# Altium schematic sheet Input_scheme.SchDoc(Input_scheme)
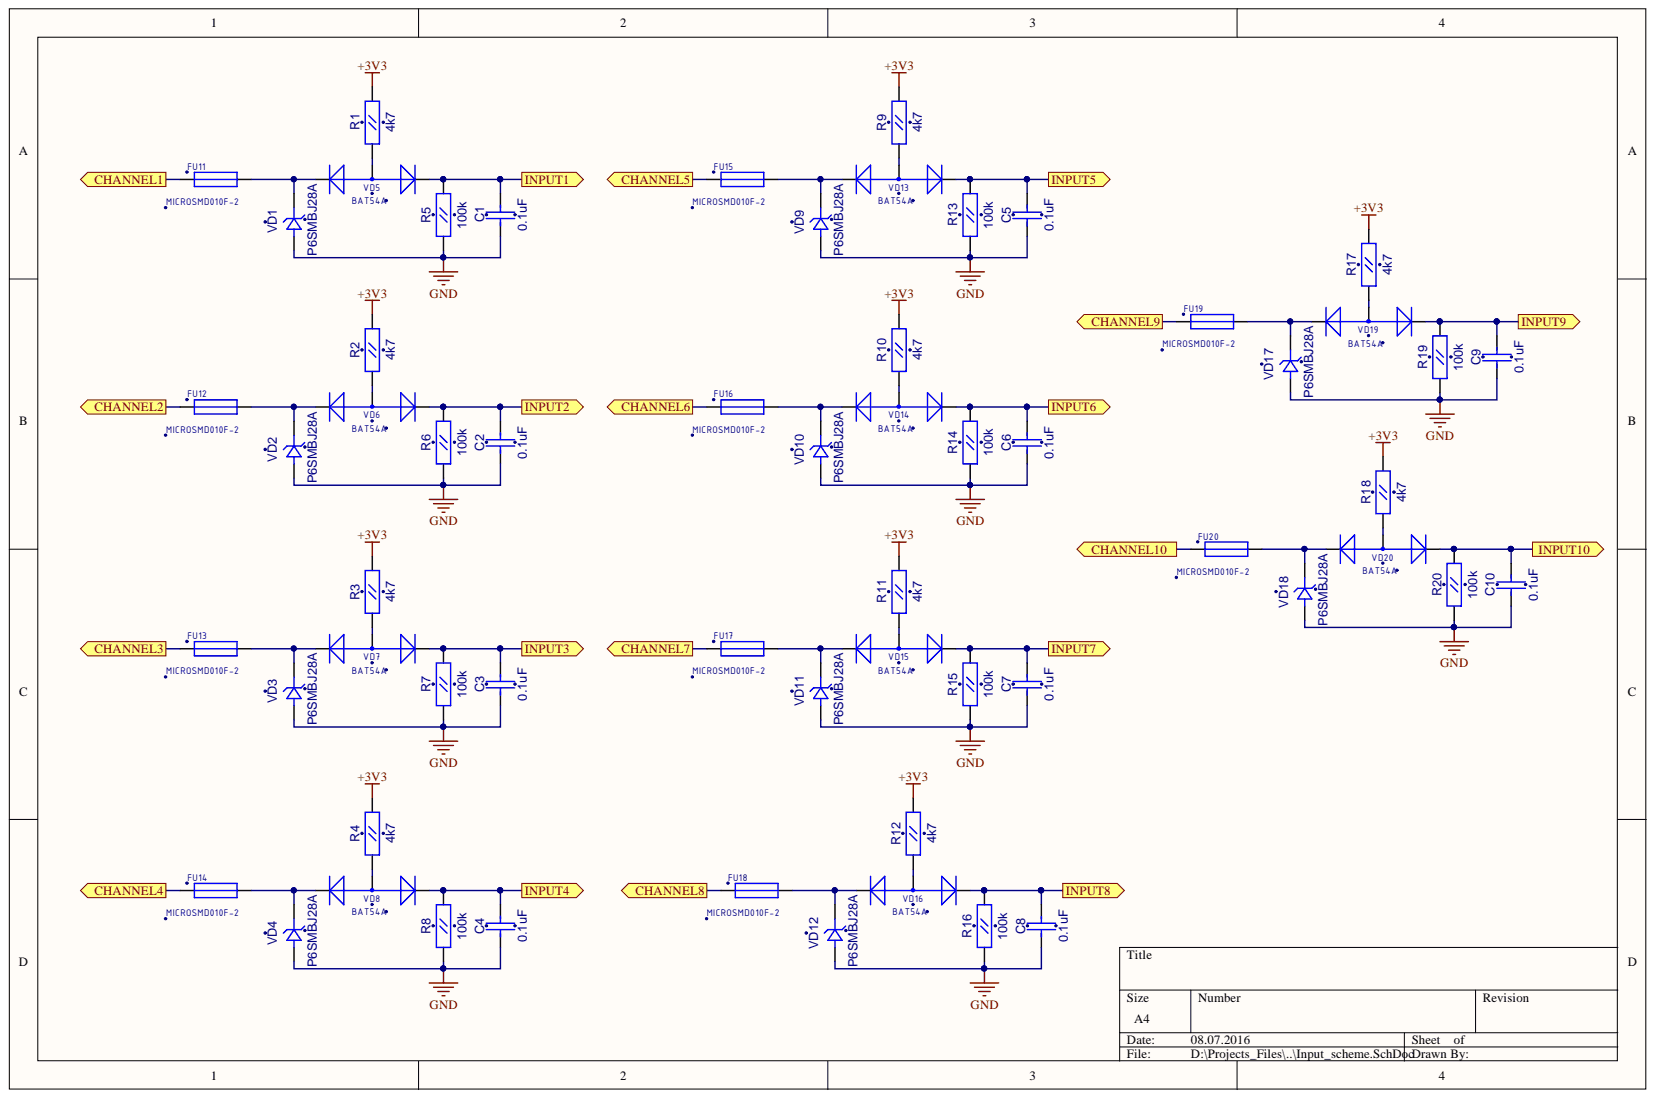
[diagram p0: Input_scheme.SchDoc]

## Parts
C1  0.1uF  [CC0603]
C2  0.1uF  [CC0603]
C3  0.1uF  [CC0603]
C4  0.1uF  [CC0603]
C5  0.1uF  [CC0603]
C6  0.1uF  [CC0603]
C7  0.1uF  [CC0603]
C8  0.1uF  [CC0603]
C9  0.1uF  [CC0603]
C10  0.1uF  [CC0603]
FU11  MICROSMD010F-2  [RC1210]
FU12  MICROSMD010F-2  [RC1210]
FU13  MICROSMD010F-2  [RC1210]
FU14  MICROSMD010F-2  [RC1210]
FU15  MICROSMD010F-2  [RC1210]
FU16  MICROSMD010F-2  [RC1210]
FU17  MICROSMD010F-2  [RC1210]
FU18  MICROSMD010F-2  [RC1210]
FU19  MICROSMD010F-2  [RC1210]
FU20  MICROSMD010F-2  [RC1210]
R1  4k7  [RC0603]
R2  4k7  [RC0603]
R3  4k7  [RC0603]
R4  4k7  [RC0603]
R5  100k  [RC0603]
R6  100k  [RC0603]
R7  100k  [RC0603]
R8  100k  [RC0603]
R9  4k7  [RC0603]
R10  4k7  [RC0603]
R11  4k7  [RC0603]
R12  4k7  [RC0603]
R13  100k  [RC0603]
R14  100k  [RC0603]
R15  100k  [RC0603]
R16  100k  [RC0603]
R17  4k7  [RC0603]
R18  4k7  [RC0603]
R19  100k  [RC0603]
R20  100k  [RC0603]
VD1  P6SMBJ28A  [SMB]
VD2  P6SMBJ28A  [SMB]
VD3  P6SMBJ28A  [SMB]
VD4  P6SMBJ28A  [SMB]
VD5  BAT54A  [SOT-23(123)]
VD6  BAT54A  [SOT-23(123)]
VD7  BAT54A  [SOT-23(123)]
VD8  BAT54A  [SOT-23(123)]
VD9  P6SMBJ28A  [SMB]
VD10  P6SMBJ28A  [SMB]
VD11  P6SMBJ28A  [SMB]
VD12  P6SMBJ28A  [SMB]
VD13  BAT54A  [SOT-23(123)]
VD14  BAT54A  [SOT-23(123)]
VD15  BAT54A  [SOT-23(123)]
VD16  BAT54A  [SOT-23(123)]
VD17  P6SMBJ28A  [SMB]
VD18  P6SMBJ28A  [SMB]
VD19  BAT54A  [SOT-23(123)]
VD20  BAT54A  [SOT-23(123)]

## Ports
CHANNEL1, CHANNEL2, CHANNEL3, CHANNEL4, CHANNEL5, CHANNEL6, CHANNEL7, CHANNEL8, CHANNEL9, CHANNEL10, INPUT1, INPUT2, INPUT3, INPUT4, INPUT5, INPUT6, INPUT7, INPUT8, INPUT9, INPUT10

## Nets
+3V3: R1.2 R2.2 R3.2 R4.2 R9.2 R10.2 R11.2 R12.2 R17.2 R18.2
GND: C1.1 C2.1 C3.1 C4.1 C5.1 C6.1 C7.1 C8.1 C9.1 C10.1 R5.1 R6.1 R7.1 R8.1 R13.1 R14.1 R15.1 R16.1 R19.1 R20.1 VD1.1 VD2.1 VD3.1 VD4.1 VD9.1 VD10.1 VD11.1 VD12.1 VD17.1 VD18.1
CHANNEL1: FU11.1 ; port CHANNEL1
CHANNEL2: FU12.1 ; port CHANNEL2
CHANNEL3: FU13.1 ; port CHANNEL3
CHANNEL4: FU14.1 ; port CHANNEL4
CHANNEL5: FU15.1 ; port CHANNEL5
CHANNEL6: FU16.1 ; port CHANNEL6
CHANNEL7: FU17.1 ; port CHANNEL7
CHANNEL8: FU18.1 ; port CHANNEL8
CHANNEL9: FU19.1 ; port CHANNEL9
CHANNEL10: FU20.1 ; port CHANNEL10
INPUT1: C1.2 R5.2 VD5.2 ; port INPUT1
INPUT2: C2.2 R6.2 VD6.2 ; port INPUT2
INPUT3: C3.2 R7.2 VD7.2 ; port INPUT3
INPUT4: C4.2 R8.2 VD8.2 ; port INPUT4
INPUT5: C5.2 R13.2 VD13.2 ; port INPUT5
INPUT6: C6.2 R14.2 VD14.2 ; port INPUT6
INPUT7: C7.2 R15.2 VD15.2 ; port INPUT7
INPUT8: C8.2 R16.2 VD16.2 ; port INPUT8
INPUT9: C9.2 R19.2 VD19.2 ; port INPUT9
INPUT10: C10.2 R20.2 VD20.2 ; port INPUT10
NetFU11_2: FU11.2 VD1.2 VD5.1
NetFU12_2: FU12.2 VD2.2 VD6.1
NetFU13_2: FU13.2 VD3.2 VD7.1
NetFU14_2: FU14.2 VD4.2 VD8.1
NetFU15_2: FU15.2 VD9.2 VD13.1
NetFU16_2: FU16.2 VD10.2 VD14.1
NetFU17_2: FU17.2 VD11.2 VD15.1
NetFU18_2: FU18.2 VD12.2 VD16.1
NetFU19_2: FU19.2 VD17.2 VD19.1
NetFU20_2: FU20.2 VD18.2 VD20.1
NetR1_1: R1.1 VD5.3
NetR2_1: R2.1 VD6.3
NetR3_1: R3.1 VD7.3
NetR4_1: R4.1 VD8.3
NetR9_1: R9.1 VD13.3
NetR10_1: R10.1 VD14.3
NetR11_1: R11.1 VD15.3
NetR12_1: R12.1 VD16.3
NetR17_1: R17.1 VD19.3
NetR18_1: R18.1 VD20.3

## Library data of the parts
C1-C10 = CC0603: Component Kind: Standard; Description: CC0603; Value: 0.1uF; Library Name: gprs_modem_2.SchLib
FU11-FU20 = MICROSMD: Component Kind: Standard; Description: MICROSMD; Value: MICROSMD010F-2; Library Name: gprs_modem_2.SchLib
R1-R20 = RC0603: Component Kind: Standard; Description: RC0603; Library Name: gprs_modem_2.SchLib
  R1-R4 R9-R12 R17 R18: Value: 4k7
  R5-R8 R13-R16 R19 R20: Value: 100k
VD1-VD4 VD9-VD12 VD17 VD18 = SMBJ: Component Kind: Standard; Description: SMBJ; Value: P6SMBJ28A; Library Name: gprs_modem.SchLib
VD5-VD8 VD13-VD16 VD19 VD20 = BAT54A: ComponentField6: Published 8-Jun-2000, Modified 11-July-2000: SIM Model Added.; Component Kind: Standard; Description: BAT54A; Simulation: DIODE
